# Altium schematic sheet Altimeter.SchDoc(Altimeter)
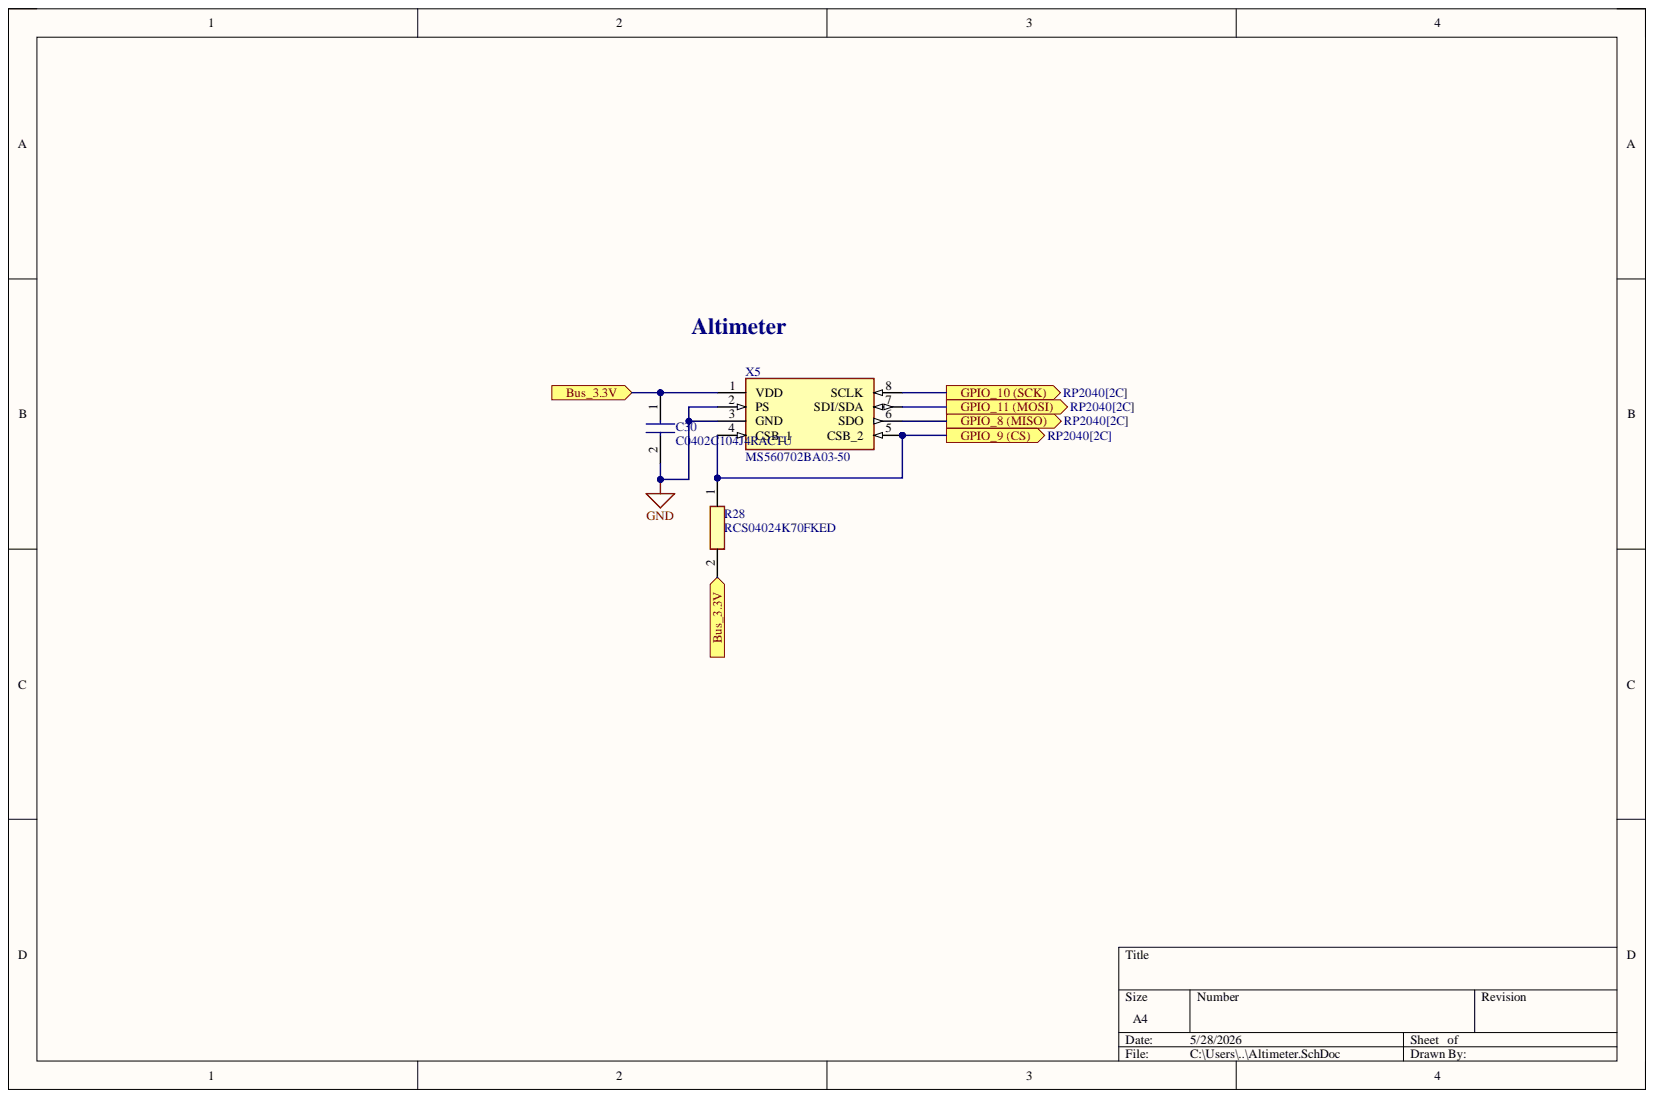
[diagram p0: Altimeter.SchDoc]

## Parts
C30  C0402C104J4RACTU  [C0402C104J4RACTU]
R28  RCS04024K70FKED  [RCS0402_E3]
X5  MS560702BA03-50  [MS5607-02BA03]

## Ports
Bus_3.3V, GPIO_8 (MISO), GPIO_9 (CS), GPIO_10 (SCK), GPIO_11 (MOSI)

## Nets
GND: C30.2 X5.2(PS) X5.3(GND)
Bus_3.3V: C30.1 R28.2 X5.1(VDD) ; port Bus_3.3V
GPIO_8 (MISO): X5.6(SDO) ; port GPIO_8 (MISO)
GPIO_9 (CS): R28.1 X5.4(CSB_1) X5.5(CSB_2) ; port GPIO_9 (CS)
GPIO_10 (SCK): X5.8(SCLK) ; port GPIO_10 (SCK)
GPIO_11 (MOSI): X5.7(SDI/SDA) ; port GPIO_11 (MOSI)

## Library data of the parts
C30 = C0402C104J4RACTU: Condensateur 100nF; Manufacturer_Name: KEMET; Arrow Part Number: C0402C104J4RACTU; Arrow Price/Stock: https://www.arrow.com/en/products/c0402c104j4ractu/kemet-corporation?utm_currency=USD&region=nac; Component Kind: Standard; Datasheet Link: https://content.kemet.com/datasheets/KEM_C1002_X7R_SMD.pdf; Height: 0.55mm; Manufacturer_Part_Number: C0402C104J4RACTU; Mouser Part Number: 80-C0402C104J4R; Mouser Price/Stock: https://www.mouser.co.uk/ProductDetail/KEMET/C0402C104J4RACTU?qs=hOC58ESJ5%2FTi6zdFDTVLPg%3D%3D; Library Name: ADSC_V2.IntLib
R28 = RCS04024K70FKED: Résistance 4.7kohm; Manufacturer_Name: Vishay; Arrow Part Number: RCS04024K70FKED; Arrow Price/Stock: https://www.arrow.com/en/products/rcs04024k70fked/vishay?utm_currency=USD&region=nac; Component Kind: Standard; Datasheet Link: https://www.vishay.com/docs/20065/rcse3.pdf; Manufacturer_Part_Number: RCS04024K70FKED; Mouser Part Number: 71-RCS04024K70FKED; Mouser Price/Stock: https://www.mouser.co.uk/ProductDetail/Vishay-Dale/RCS04024K70FKED?qs=NKmfXavxMaw%252Bu6VUO3JuMQ%3D%3D; Library Name: ADSC_V2.IntLib
X5 = MS560702BA03-50: Integrated Circuit; Manufacturer_Name: TE Connectivity; Arrow Part Number: MS560702BA03-50; Arrow Price/Stock: https://www.arrow.com/en/products/ms560702ba03-50/te-connectivity?utm_currency=USD&region=europe; Component Kind: Standard; Datasheet Link: https://www.te.com/commerce/DocumentDelivery/DDEController?Action=srchrtrv&DocNm=MS5607-02BA03&DocType=Data%20Sheet&DocLang=English&PartCntxt=MS560702BA03-50&DocFormat=pdf; Manufacturer_Part_Number: MS560702BA03-50; Mouser Part Number: 824-MS560702BA03-50; Mouser Price/Stock: https://www.mouser.co.uk/ProductDetail/Measurement-Specialties/MS560702BA03-50?qs=urSpXqmdEVImCZG1H%252BT8ng%3D%3D; Library Name: ADSC_V2.IntLib
